# Altium schematic sheet FPGA_MIPI.SchDoc
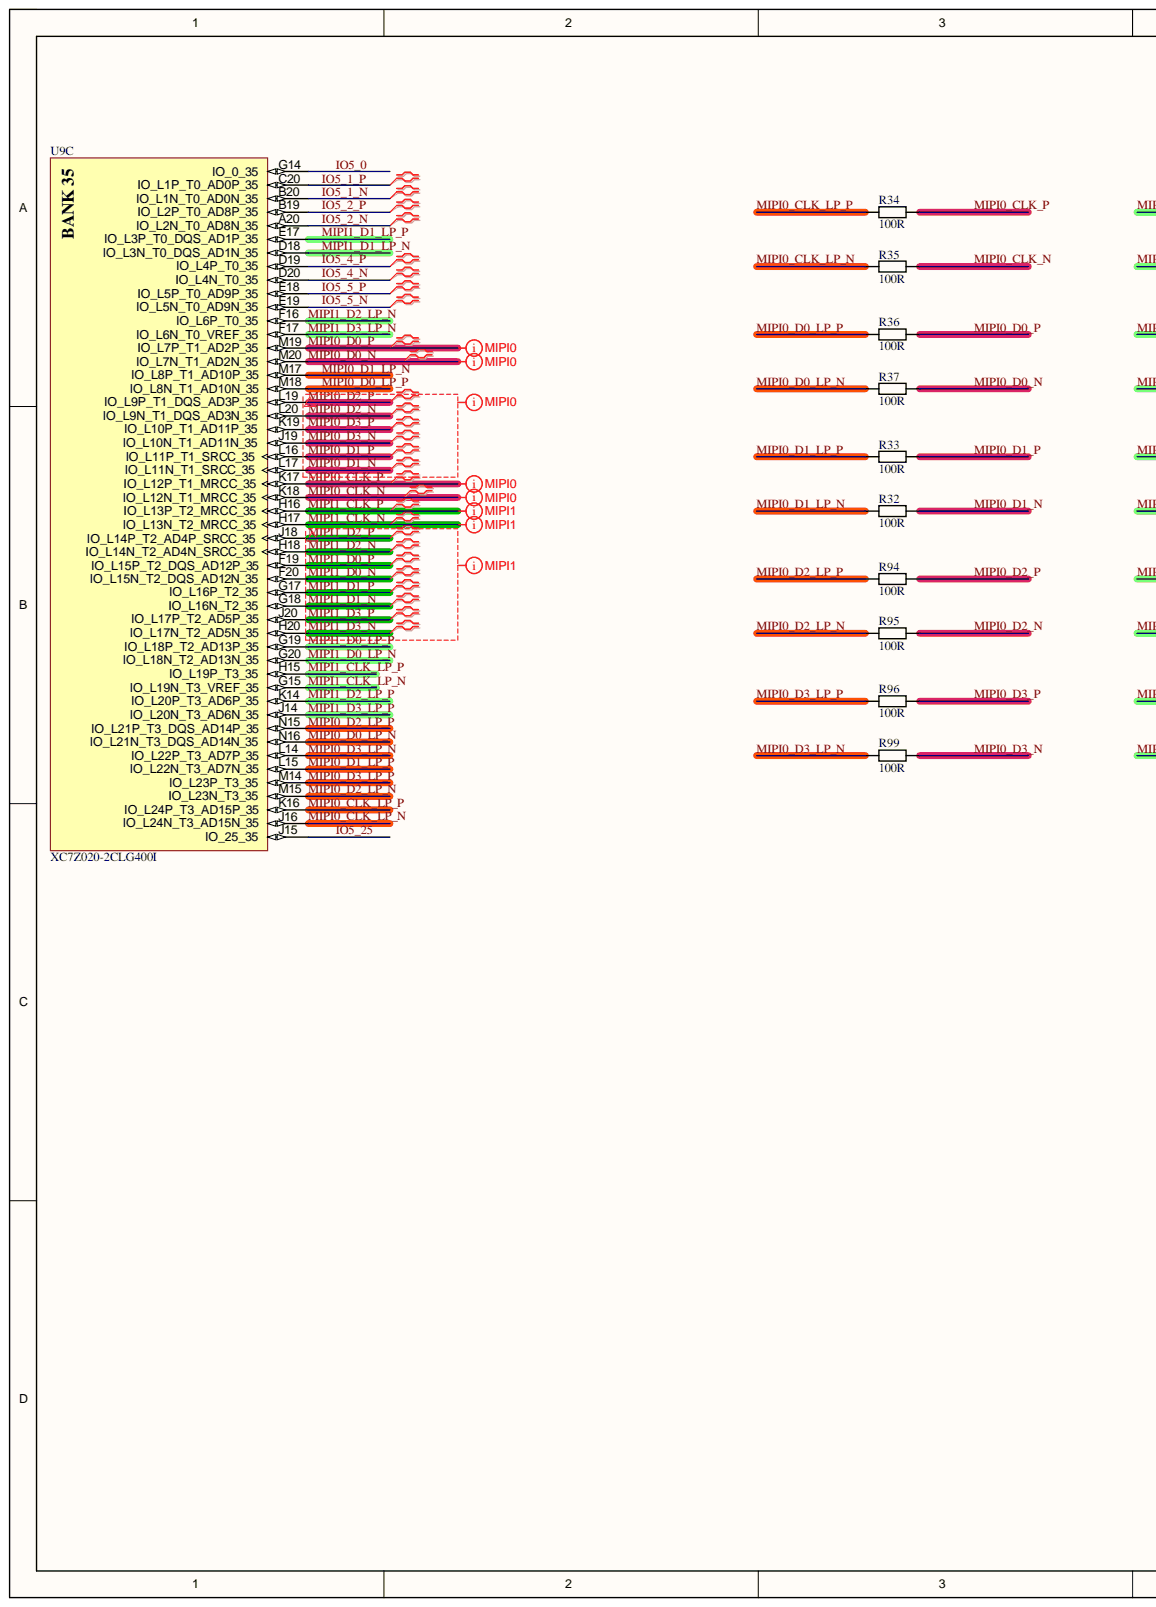
[diagram p0: FPGA_MIPI.SchDoc, left]
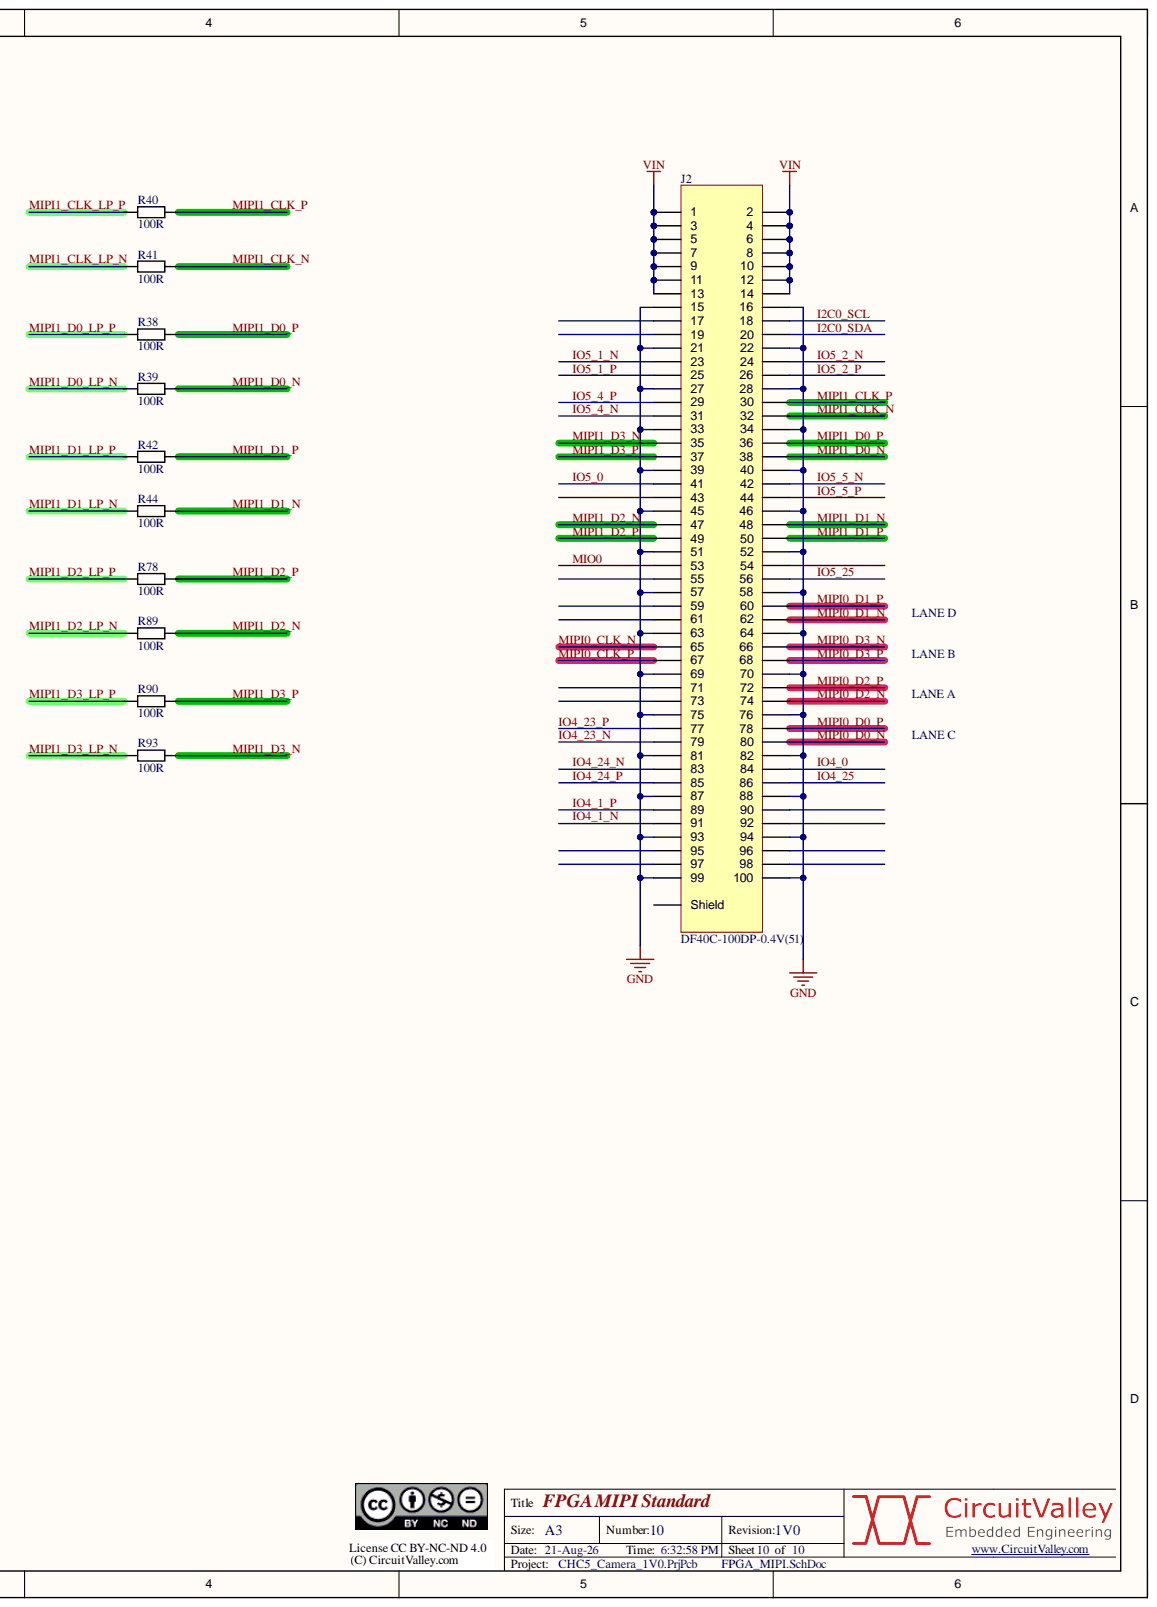
[diagram p1: FPGA_MIPI.SchDoc, right]

## Parts
J2  DF40C-100DP-0.4V(51)  [DF40C-100DP-0.4V]
R32  100R  [R0201]
R33  100R  [R0201]
R34  100R  [R0201]
R35  100R  [R0201]
R36  100R  [R0201]
R37  100R  [R0201]
R38  100R  [R0201]
R39  100R  [R0201]
R40  100R  [R0201]
R41  100R  [R0201]
R42  100R  [R0201]
R44  100R  [R0201]
R78  100R  [R0201]
R89  100R  [R0201]
R90  100R  [R0201]
R93  100R  [R0201]
R94  100R  [R0201]
R95  100R  [R0201]
R96  100R  [R0201]
R99  100R  [R0201]
U9  XC7Z020-2CLG400I  [CLG400]  (part C)

## Nets
GND: J2.15 J2.16 J2.21 J2.22 J2.27 J2.28 J2.33 J2.34 J2.39 J2.40 J2.45 J2.46 J2.51 J2.52 J2.57 J2.58 J2.63 J2.64 J2.69 J2.70 J2.75 J2.76 J2.81 J2.82 J2.87 J2.88 J2.93 J2.94 J2.99 J2.100
VIN: J2.1 J2.2 J2.3 J2.4 J2.5 J2.6 J2.7 J2.8 J2.9 J2.10 J2.11 J2.12 J2.13 J2.14
I2C0_SCL: J2.18
I2C0_SDA: J2.20
IO4_0: J2.84
IO4_1_N: J2.91
IO4_1_P: J2.89
IO4_23_N: J2.79
IO4_23_P: J2.77
IO4_24_N: J2.83
IO4_24_P: J2.85
IO4_25: J2.86
IO5_0: J2.41 U9.G14(IO_0_35)
IO5_1_N: J2.23 U9.B20(IO_L1N_T0_AD0N_35)
IO5_1_P: J2.25 U9.C20(IO_L1P_T0_AD0P_35)
IO5_2_N: J2.24 U9.A20(IO_L2N_T0_AD8N_35)
IO5_2_P: J2.26 U9.B19(IO_L2P_T0_AD8P_35)
IO5_4_N: J2.31 U9.D20(IO_L4N_T0_35)
IO5_4_P: J2.29 U9.D19(IO_L4P_T0_35)
IO5_5_N: J2.42 U9.E19(IO_L5N_T0_AD9N_35)
IO5_5_P: J2.44 U9.E18(IO_L5P_T0_AD9P_35)
IO5_25: J2.56 U9.J15(IO_25_35)
MIO0: J2.53
MIPI0_CLK_LP_N: R35.1 U9.J16(IO_L24N_T3_AD15N_35)
MIPI0_CLK_LP_P: R34.1 U9.K16(IO_L24P_T3_AD15P_35)
MIPI0_CLK_N: J2.65 R35.2 U9.K18(IO_L12N_T1_MRCC_35)
MIPI0_CLK_P: J2.67 R34.2 U9.K17(IO_L12P_T1_MRCC_35)
MIPI0_D0_LP_N: R37.1 U9.N16(IO_L21N_T3_DQS_AD14N_35)
MIPI0_D0_LP_P: R36.1 U9.M18(IO_L8N_T1_AD10N_35)
MIPI0_D0_N: J2.80 R37.2 U9.M20(IO_L7N_T1_AD2N_35)
MIPI0_D0_P: J2.78 R36.2 U9.M19(IO_L7P_T1_AD2P_35)
MIPI0_D1_LP_N: R32.1 U9.M17(IO_L8P_T1_AD10P_35)
MIPI0_D1_LP_P: R33.1 U9.L15(IO_L22N_T3_AD7N_35)
MIPI0_D1_N: J2.62 R32.2 U9.L17(IO_L11N_T1_SRCC_35)
MIPI0_D1_P: J2.60 R33.2 U9.L16(IO_L11P_T1_SRCC_35)
MIPI0_D2_LP_N: R95.1 U9.M15(IO_L23N_T3_35)
MIPI0_D2_LP_P: R94.1 U9.N15(IO_L21P_T3_DQS_AD14P_35)
MIPI0_D2_N: J2.74 R95.2 U9.L20(IO_L9N_T1_DQS_AD3N_35)
MIPI0_D2_P: J2.72 R94.2 U9.L19(IO_L9P_T1_DQS_AD3P_35)
MIPI0_D3_LP_N: R99.1 U9.L14(IO_L22P_T3_AD7P_35)
MIPI0_D3_LP_P: R96.1 U9.M14(IO_L23P_T3_35)
MIPI0_D3_N: J2.66 R99.2 U9.J19(IO_L10N_T1_AD11N_35)
MIPI0_D3_P: J2.68 R96.2 U9.K19(IO_L10P_T1_AD11P_35)
MIPI1_CLK_LP_N: R41.1 U9.G15(IO_L19N_T3_VREF_35)
MIPI1_CLK_LP_P: R40.1 U9.H15(IO_L19P_T3_35)
MIPI1_CLK_N: J2.32 R41.2 U9.H17(IO_L13N_T2_MRCC_35)
MIPI1_CLK_P: J2.30 R40.2 U9.H16(IO_L13P_T2_MRCC_35)
MIPI1_D0_LP_N: R39.1 U9.G20(IO_L18N_T2_AD13N_35)
MIPI1_D0_LP_P: R38.1 U9.G19(IO_L18P_T2_AD13P_35)
MIPI1_D0_N: J2.38 R39.2 U9.F20(IO_L15N_T2_DQS_AD12N_35)
MIPI1_D0_P: J2.36 R38.2 U9.F19(IO_L15P_T2_DQS_AD12P_35)
MIPI1_D1_LP_N: R44.1 U9.D18(IO_L3N_T0_DQS_AD1N_35)
MIPI1_D1_LP_P: R42.1 U9.E17(IO_L3P_T0_DQS_AD1P_35)
MIPI1_D1_N: J2.48 R44.2 U9.G18(IO_L16N_T2_35)
MIPI1_D1_P: J2.50 R42.2 U9.G17(IO_L16P_T2_35)
MIPI1_D2_LP_N: R89.1 U9.F16(IO_L6P_T0_35)
MIPI1_D2_LP_P: R78.1 U9.K14(IO_L20P_T3_AD6P_35)
MIPI1_D2_N: J2.47 R89.2 U9.H18(IO_L14N_T2_AD4N_SRCC_35)
MIPI1_D2_P: J2.49 R78.2 U9.J18(IO_L14P_T2_AD4P_SRCC_35)
MIPI1_D3_LP_N: R93.1 U9.F17(IO_L6N_T0_VREF_35)
MIPI1_D3_LP_P: R90.1 U9.J14(IO_L20N_T3_AD6N_35)
MIPI1_D3_N: J2.35 R93.2 U9.H20(IO_L17N_T2_AD5N_35)
MIPI1_D3_P: J2.37 R90.2 U9.J20(IO_L17P_T2_AD5P_35)

Not connected: J2 17 19 43 54 55 59 61 71 73 90 92 95 96 97 98 S1(Shield)

## Library data of the parts
J2 = DF40C-100DP-0.4V(51): Hiros 100 pin Connector Header; Component Kind: Standard; Library Name: cvlib.SchLib
R32-R42 R44 R78 R89 R90 R93-R96 R99 = RES_0201: Component Kind: Standard; Value: 100R; Library Name: cvlib.SchLib
U9 = XC7Z020-2CLG400I: 733 MHz Zynq™-7000 AP SoC, 130 PS I/O, 125 FPGA I/O, 400-Ball BGA, Speed Grade 2, Industrial Grade, Pb-Free; Manufacturer: Xilinx; Extensible Block RAM (# 36Kb Blocks): 560 KB (140); Flip Flops: 106400; LUTs: 53200; Speed Grade: -2; Code_JEDEC: MO-275-MMAA-1; Component Kind: Standard; ComponentLink1Description: Datasheet; ComponentLink1URL: http://www.xilinx.com/support/documentation/data_sheets/ds190-Zynq-7000-Overview.pdf; ComponentLink2Description: Package Specification; ComponentLink2URL: http://www.xilinx.com/support/documentation/user_guides/ug865-Zynq-7000-Pkg-Pinout.pdf; ComponentLink3Description: DC and AC Switching Characteristics; ComponentLink3URL: http://www.xilinx.com/support/documentation/data_sheets/ds187-XC7Z010-XC7Z020-Data-Sheet.pdf; ComponentLink4Description: Package File; ComponentLink4URL: http://www.xilinx.com/support/packagefiles/z7packages/xc7z020clg400pkg.txt; ComponentLink5Description: Packaging and Pinout; ComponentLink5URL: http://www.xilinx.com/support/documentation/user_guides/ug865-Zynq-7000-Pkg-Pinout.pdf; ComponentLink6Description: PCB and Layout Guide; ComponentLink6URL: http://www.xilinx.com/support/documentation/user_guides/ug483_7Series_PCB.pdf; DatasheetVersion: v1.2; Generic Part Number: XC7Z020; Library Name: Xilinx Zynq-7000.SchLib; Mounting Technology: Surface Mount; PackageDescription: Wire-Bond Chip-Scale BGA, Body 17 x 17 mm, 0.8 mm Pitch; PackageReference: CLG400; PackageVersion: Rev 1.1; PartNumber: XC7Z020-2CLG400I; RoHS: Pb-Free
